# Altium schematic sheet STM32_ nRF24GHz.SchDoc
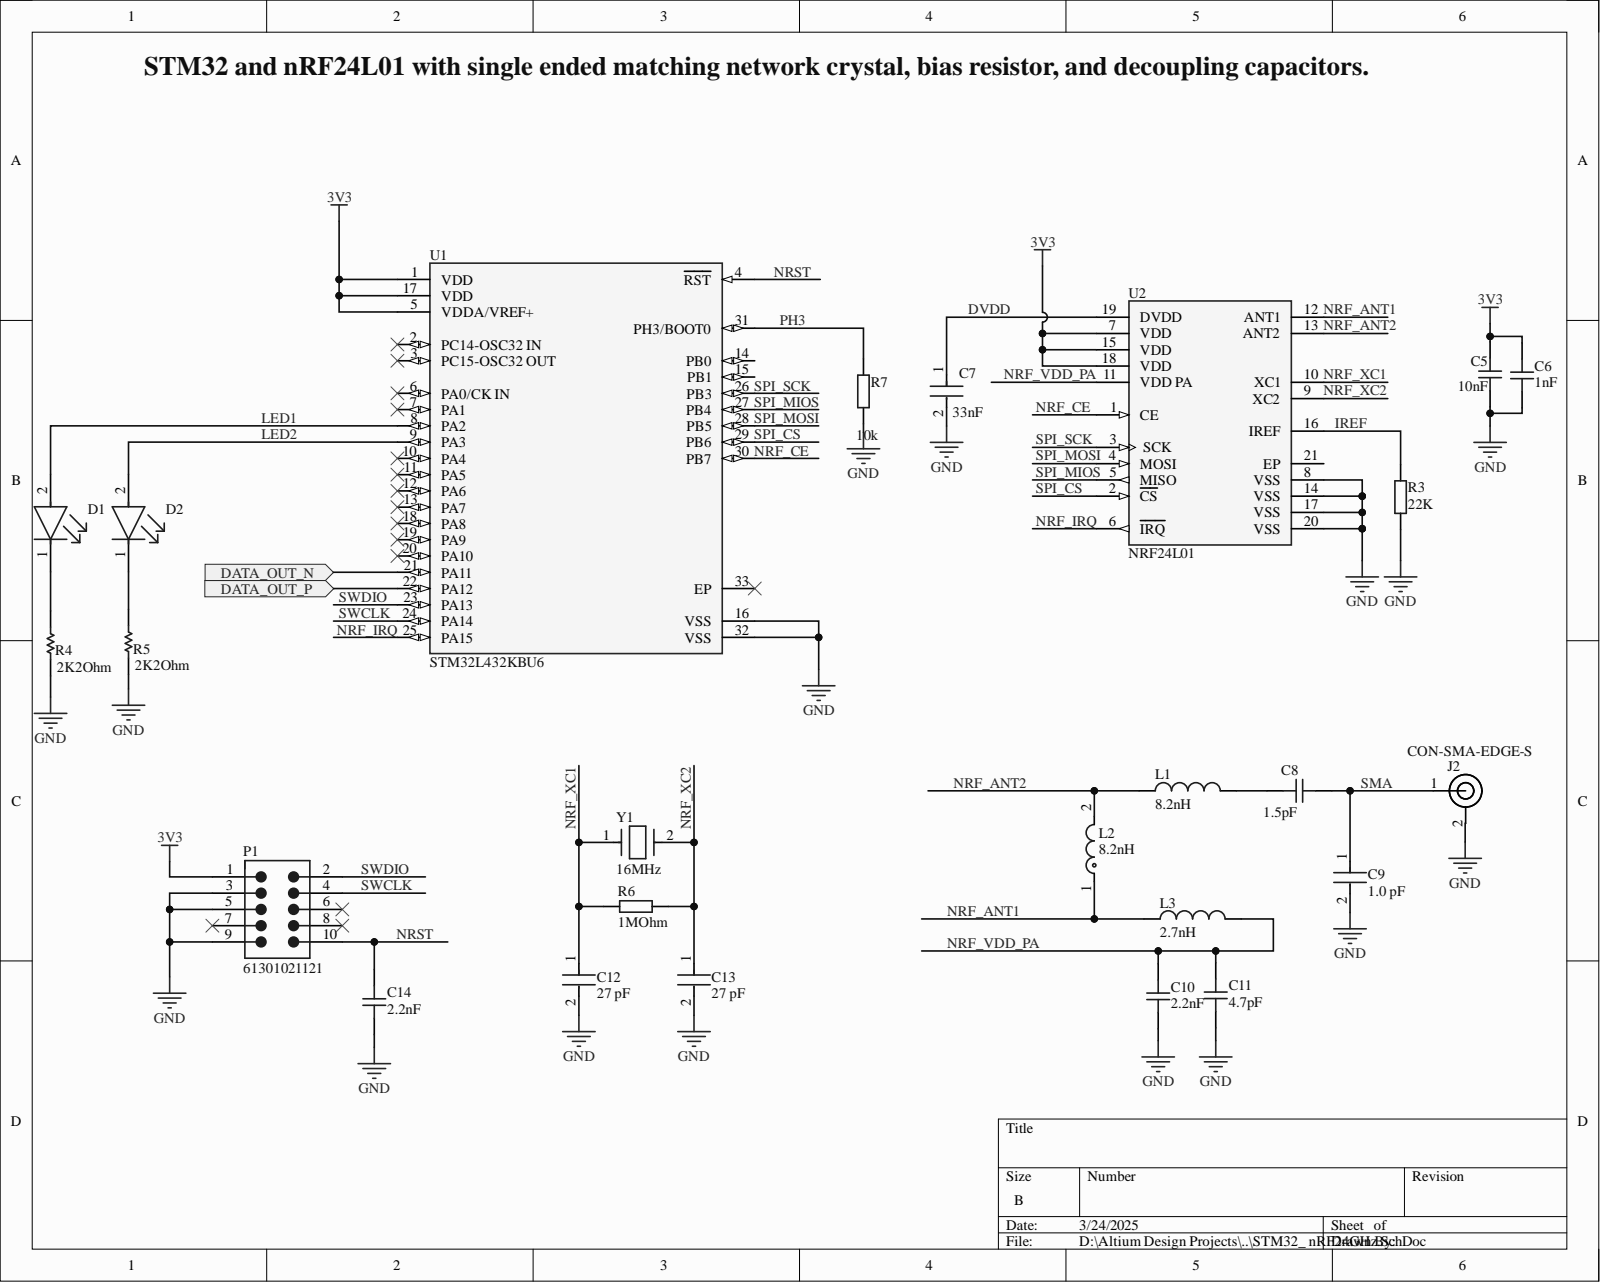
[diagram p0: STM32_ nRF24GHz.SchDoc]

## Parts
C5  Parameter 1: 10nF  [CAP_0402]  (hidden comment: C0402)
C6  Parameter 1: 1nF  [CAP_0402]  (hidden comment: C0402)
C7  value 33nF  Capacitance: 33nF  [FP-C0402-BB-MFG]  (hidden comment: C0402C333K4RACTU)
C8  Parameter 1: 1.5pF  [CAP_0402]  (hidden comment: C0402)
C9  value 1.0 pF  Capacitance: 1.0 pF  [FP-C0402-BB-MFG]  (hidden comment: C0402C109C5GACTU)
C10  Parameter 1: 2.2nF  [CAP_0402]  (hidden comment: C0402)
C11  Parameter 1: 4.7pF  [CAP_0402]  (hidden comment: C0402)
C12  value 27 pF  Capacitance: 27 pF  [FP-C0402-BB-MFG]  (hidden comment: C0402C270J5GACTU)
C13  value 27 pF  Capacitance: 27 pF  [FP-C0402-BB-MFG]  (hidden comment: C0402C270J5GACTU)
C14  Parameter 1: 2.2nF  [CAP_0402]  (hidden comment: C0402)
D1  LTST-C190GKT  [FP-LTST-C190GKT-MFG]  (value not drawn)
D2  LTST-C190GKT  [FP-LTST-C190GKT-MFG]  (value not drawn)
J2  CON-SMA-EDGE-S  [CON-SMA-EDGE-S]
L1  Inductance: 8.2nH  [WE-TCI_0402]  (hidden comment: 744901082)
L2  Inductance: 8.2nH  [FP-LQG15HH_02-MFG]  (hidden comment: LQG15HN8N2J02D)
L3  Inductance: 2.7nH  [WE-TCI_0402]  (hidden comment: 744901027)
P1  61301021121  [61301021121]
R3  22K  [RES_0402]
R4  Parameter 1: 2K2Ohm  [RESC1005X40X25LL05T05]  (hidden comment: CRCW040242K2FKED)
R5  Parameter 1: 2K2Ohm  [RESC1005X40X25LL05T05]  (hidden comment: CRCW040242K2FKED)
R6  1MOhm  [RES_0402]
R7  10k  [RES_0402]
U1  STM32L432KBU6  [FP-UFQFPN32-A0B8-MFG]
U2  NRF24L01  [FP-NRF24L01-IPC_C]
Y1  Frequency: 16MHz  [FP-ABM3-MFG]  (hidden comment: ABM3-16.000MHZ-D2Y-T)

## Ports
DATA_OUT_N, DATA_OUT_P

## Nets
3V3: C5.2 C6.2 P1.1 U1.1(VDD) U1.5(VDDA/VREF+) U1.17(VDD) U2.7(VDD) U2.15(VDD) U2.18(VDD)
GND: C5.1 C6.1 C7.2 C9.2 C10.1 C11.1 C12.2 C13.2 C14.1 J2.2 P1.3 P1.5 P1.9 R3.1 R4.1 R5.1 R7.2 U1.16(VSS) U1.32(VSS) U2.8(VSS) U2.14(VSS) U2.17(VSS) U2.20(VSS)
DATA_OUT_N: U1.21(PA11) ; port DATA_OUT_N
DATA_OUT_P: U1.22(PA12) ; port DATA_OUT_P
DVDD: C7.1 U2.19(DVDD)
IREF: R3.2 U2.16(IREF)
LED1: D1.2 U1.8(PA2)
LED2: D2.2 U1.9(PA3)
NRF_ANT1: L2.1 L3.1 U2.12(ANT1)
NRF_ANT2: L1.1 L2.2 U2.13(ANT2)
NRF_CE: U1.30(PB7) U2.1(CE)
NRF_IRQ: U1.25(PA15) U2.6(IRQ)
NRF_VDD_PA: C10.2 C11.2 L3.2 U2.11(VDD_PA)
NRF_XC1: C12.1 R6.2 U2.10(XC1) Y1.1
NRF_XC2: C13.1 R6.1 U2.9(XC2) Y1.2
NRST: C14.2 P1.10 U1.4(RST)
PH3: R7.1 U1.31(PH3/BOOT0)
SMA: C8.2 C9.1 J2.1
SPI_CS: U1.29(PB6) U2.2(CS)
SPI_MIOS: U1.27(PB4) U2.5(MISO)
SPI_MOSI: U1.28(PB5) U2.4(MOSI)
SPI_SCK: U1.26(PB3) U2.3(SCK)
SWCLK: P1.4 U1.24(PA14)
SWDIO: P1.2 U1.23(PA13)
NetC8_1: C8.1 L1.2
NetD1_1: D1.1 R4.2
NetD2_1: D2.1 R5.2

Not connected: P1 6 7 8; U1 2(PC14-OSC32_IN) 3(PC15-OSC32_OUT) 6(PA0/CK_IN) 7(PA1) 10(PA4) 11(PA5) 12(PA6) 13(PA7) 14(PB0) 15(PB1) 18(PA8) 19(PA9) 20(PA10) 33(EP); U2 21(EP)

## Library data of the parts
C5 C6 C8 C10 C11 C14 = CAP_0402: Capacitor SMD 0402; Component Kind: Standard; Library Name: Schematic-Lib-PhilsLab.IntLib
C7 = CMP-2008-03182-2: 0402 C 33nF Ceramic Multilayer Capacitor; 16 V; +125degC; X7R Dielectric; +/-10%; Manufacturer: Kemet; Temperature Coefficient: 15%; Tolerance: 0.1; Voltage Rating: 16 V; Automotive: No; Category: Cap; Component Kind: Standard; ComponentLink2Description: Datasheet; ComponentLink2URL: https://content.kemet.com/datasheets/KEM_C1002_X7R_SMD.pdf; Device Class L1: Passive Components; Device Class L2: Capacitors; Device Class L3: Ceramic Capacitors; Digikey Description: 0402 C 33nF Ceramic Multilayer Capacitor; 16 V; +125degC; X7R Dielectric; +/-10%; Height: 0.55mm; Ipc Land Pattern Name: CAPC10050X50; Lead Free: Yes; Library Name: Altium Content Vault; Manufacturer Part Number: C0402C333K4RACTU; Material: Ceramic; Mouser Description: 0402 C 33nF Ceramic Multilayer Capacitor; 16 V; +125degC; X7R Dielectric; +/-10%; Octopart: https://octopart.com/c0402c333k4ractu-kemet-128815; Package: C0402-BB; RoHS: TRUE; Temperature: -55°C to +125°C; Temperature Characteristic: X7R; Temperature Range High: +125°C; Temperature Range Low: -55°C
C9 = CMP-2008-03470-3: CAP CER 1PF 50V NP0 0402; Manufacturer: Kemet; Material: Ceramic; Temperature Characteristic: C0G; Temperature Coefficient: 15%; Tolerance: 0.25 pF; Automotive: No; Category: Cap; Component Kind: Standard; ComponentLink2Description: Datasheet; ComponentLink2URL: https://content.kemet.com/datasheets/KEM_C1002_X7R_SMD.pdf; Device Class L1: Passive Components; Device Class L2: Capacitors; Device Class L3: Ceramic Capacitors; Digikey Description: CAP CER 1PF 50V NP0 0402; Height: 0.55mm; Ipc Land Pattern Name: CAPC10050X50; Lead Free: Yes; Library Name: Altium Content Vault; Manufacturer Part Number: C0402C109C5GACTU; Mouser Description: Multilayer Ceramic Capacitors MLCC - SMD/SMT 50volts 1.0pF C0G .25pF; Octopart: https://octopart.com/c0402c109c5gactu-kemet-9138550; Package: C0402-BB; RoHS: TRUE; Temperature: -55°C to +125°C; Temperature Range High: +125°C; Temperature Range Low: -55°C; Voltage Rating: 50 V
C12 C13 = CMP-1034-00963-6: CAP CER 27PF 50V C0G/NP0 0402; Manufacturer: Kemet; Material: Ceramic; Temperature Characteristic: C0G; Temperature Coefficient: 15%; Tolerance: 0.05; Automotive: No; Category: Cap; Component Kind: Standard; ComponentLink2Description: Datasheet; ComponentLink2URL: https://content.kemet.com/datasheets/KEM_C1002_X7R_SMD.pdf; Device Class L1: Passive Components; Device Class L2: Capacitors; Device Class L3: Ceramic Capacitors; Digikey Description: CAP CER 27PF 50V C0G/NP0 0402; Height: 0.55mm; Ipc Land Pattern Name: CAPC10050X50; Lead Free: Yes; Library Name: Altium Content Vault; Manufacturer Part Number: C0402C270J5GACTU; Mouser Description: Multilayer Ceramic Capacitor, C Series, 27 pF, - 5%, C0G / NP0, 50 V, 0402 [1005 Metric]; Octopart: https://octopart.com/c0402c270j5gactu-kemet-149444; Package: C0402-BB; RoHS: TRUE; Temperature: -55°C to +125°C; Temperature Range High: +125°C; Temperature Range Low: -55°C; Voltage Rating: 50 V
D1 D2 = CMP-22017-000005-2: LED GREEN CLEAR CHIP SMD; Dominant Wavelength: 569nm; Forward Current: 30mA; Forward Voltage: 2.1V; Lens Color: Colorless; Luminous Intensity: 6mcd; Number of Elements: 1; Power Dissipation: 100mW; Reverse Voltage: 5V; Test Current: 10mA; Viewing Angle: 130°; Voltage: 2V; Wavelength: 565nm; Case Code (Imperial): 0603; Case Code (Metric): 1608; Case/Package: 0603; Color: Green; Component Kind: Standard; Depth: 0.8mm; Height: 0.8mm; Illumination Color: Green; Lead Free: Lead Free; Length: 1.6mm; Lens Style: Clear; Lens Transparency: Clear; Library Name: Altium Content Vault; Max Operating Temperature: 85°C; Min Operating Temperature: -55°C; Mount: Surface Mount; Number of LEDs: 1; Number of Pins: 2; Package Quantity: 1; Packaging: Tape and Reel; Power Rating: 100mW; Radiation Hardening: No; Width: 0.8mm
J2 = CON-SMA-EDGE-S: RF Connector SMA Female 50 Ohms 18 GHz PCB Edge Mount; Manufacturer: RF Solutions; Component Kind: Standard; Manufacturer Part Number: CON-SMA-EDGE-S; Library Name: Schematic-Lib-PhilsLab.IntLib
L1 = CMP-03913-14415882-1: 744901082 Fixed Ind 8.2NH 220MA 1.1 Ohm SMD; DC Resistance (DCR): 540mΩ; Q Factor: 13; Self Resonant Frequency: 5.5GHz; Shielding: Unshielded; Test Frequency: 500MHz; Tolerance: 2%; Case Code (Imperial): 0402; Case Code (Metric): 1005; Case/Package: 0402; Component Kind: Standard; Composition: Thin Film; Current Rating: 220mA; Height: 320µm; Height - Seated (Max): 406.4µm; Length: 1mm; Library Name: Altium Content Vault; Max DC Current: 220mA; Max Operating Temperature: 125°C; Min Operating Temperature: -40°C; Mount: Surface Mount; NexarPartId: 14415882; Number of Pins: 2; Packaging: Digi-Reel®; REACH SVHC: Unknown; RoHS: Compliant; Termination: SMD/SMT; Weight: 652.039032µg; Width: 500µm
L2 = CMP-06042-008586-1: Multilayer type RF Inductor 8.2nH ±5% 0.25Ω 500mA 0402; Core Material: Air; Current Rating: 300mA; DC Resistance (DCR): 330mR; Q Factor: 8; Self Resonant Frequency: 3.6GHz; Shielding: Unshielded; Test Frequency: 100MHz; Case Code (Imperial): 0402; Case Code (Metric): 1005; Case/Package: 0402; Component Kind: Standard; DC Current: 300mA; Depth: 0.55mm; Height: 0.02inch; Height - Seated (Max): 0.022inch; Inductance Tolerance: 5%; Lead Free: Lead Free; Length: 1mm; Library Name: Altium Content Vault; Max DC Current: 300mA; Max Operating Temperature: 125°C; Military Standard: Not; Min Operating Temperature: -55°C; Mount: Surface Mount; Package Quantity: 1; Packaging: Tape and Reel; Radiation Hardening: No; REACH SVHC: No SVHC; Termination: SMD/SMT; Tolerance: 5%; Width: 0.5mm
L3 = CMP-03913-14415876-1: 744901027 Fixed Ind 2.7NH 440MA 300MOHM Sm; Core Material: Alumina; DC Resistance (DCR): 270mΩ; Q Factor: 13; Self Resonant Frequency: 8GHz; Shielding: Unshielded; Test Frequency: 500MHz; Case Code (Imperial): 0402; Case Code (Metric): 1005; Case/Package: 0402; Component Kind: Standard; Composition: Thin Film; Current Rating: 440mA; Height: 320µm; Height - Seated (Max): 406.4µm; Length: 1mm; Library Name: Altium Content Vault; Max DC Current: 440mA; Max Operating Temperature: 125°C; Min Operating Temperature: -40°C; Mount: Surface Mount; NexarPartId: 14415876; Number of Pins: 2; Packaging: Tape & Reel (TR); REACH SVHC: Unknown; RoHS: Compliant; Termination: SMD/SMT; Weight: 652.039032µg; Width: 500µm
P1 = CMP-1502-01084-3: NexarPartId: 18818163; Connector Type: Male Pin; Contact Resistance: 20mΩ; Current Rating: 3A; Gender: Male; Insulation: Insulated; Insulation Resistance: 1GΩ; Number of Contacts: 10; Operating Supply Voltage: 250V; Pitch: 2.54mm; Color: Black; Component Kind: Standard; Contact Gender: Male; Contact Material: Copper; Contact Mating Length: 5.9944mm; Contact Plating: Gold; Flammability Rating: UL94 V-0; Height: 2.54mm; Housing Material: PBT; Lead Pitch: 2.54mm; Length: 12.7mm; Library Name: Altium Content Vault; Max Operating Temperature: 105°C; Min Operating Temperature: -40°C; Mount: Through Hole; Number of Pins: 10; Number of Positions: 10; Number of Rows: 2; Orientation: Vertical; Packaging: Bulk; REACH SVHC: Unknown; RoHS: Compliant; Row Spacing: 2.54mm; Termination: Through Hole; Voltage Rating: 250V; Width: 12.7mm
R3 R6 R7 = RES_0402: Resistor SMD 0402; Component Kind: Standard; Library Name: Schematic-Lib-PhilsLab.IntLib
  R7: Resistance: 10k
R4 R5 = CMP-2002-08626-1: Manufacturer: Vishay Dale; Component Kind: Standard; Library Name: Altium Content Vault; Part Number: CRCW040242K2FKED
U1 = CMP-12105-000014-1: IC MCU 32BIT 128KB FLASH 32QFPN; Manufacturer: STMicroelectronics; Core Architecture: ARM Cortex-M4; Data Bus Width: 32bits; Frequency: 80MHz; Interface: I2C,SPI,UART,USART,USB,IrDA; Max Junction Temp: +105°C; Max Supply Voltage: 3.6V; Memory Size: 128KBytes; Min Supply Voltage: 1.62V; Number Of A/D Converters: 1; Number Of Adc Channels: 10; Number Of I2C Channels: 2; Number Of I/Os: 26; Number Of Timers/Counters: 8; Ram Size: 64KBytes; Ambient Temperature Range High: +85°C; Ambient Temperature Range Low: -40°C; Automotive: No; Category: IC; Component Kind: Standard; ComponentLink2Description: Datasheet; ComponentLink2URL: https://www.st.com/resource/en/datasheet/stm32l432kb.pdf; Device Class L1: Integrated Circuits (ICs); Device Class L2: Embedded Processors and Controllers; Device Class L3: Microcontrollers; Digikey Description: IC MCU 32BIT 128KB FLASH 32QFPN; Height: 0.6mm; Ipc Land Pattern Name: QFN50P500X500X55-32; Lead Free: Yes; Library Name: Altium Content Vault; Manufacturer Part Number: STM32L432KBU6; Memory Type: FLASH; Number Of Cores: 1; Number Of Spi Channels: 2; Number Of Uart Channels: 1; Octopart: https://octopart.com/stm32l432kbu6-stmicroelectronics-74568735; Package: UFQFPN32-A0B8; RoHS: TRUE; Temperature: -40°C to +85°C; Temperature Range High: +85°C; Temperature Range Low: -40°C
U2 = CMP-228436-000003-1: IC RF TXRX ISM>1GHZ 20VFQFN; Component Kind: Standard; Library Name: Altium Content Vault
Y1 = CMP-27762-004490-1: Crystal 16MHz ±20ppm 18pF SMD-2 5mm x 3.2mm; ESR (Equivalent Series Resistance): 40R; Frequency Stability: 30ppm; Case/Package: SMD/SMT; Component Kind: Standard; Frequency Tolerance: 20ppm; Height: 0.051inch; Lead Free: Lead Free; Length: 0.197inch; Library Name: Altium Content Vault; Load Capacitance: 18pF; Max Operating Temperature: 85°C; Min Operating Temperature: -40°C; Mount: Surface Mount; Number of Pins: 2; Operating Frequency: 16 MHz; Package Quantity: 1000; Packaging: Tape and Reel; Resistor Type: Ceramic; RoHS Compliant: Yes; Series: ABM3; Series Resistance: 40R; Termination: SMD/SMT; Tolerance: 20ppm; Width: 0.126inch
